# Altium schematic sheet MAIN.SchDoc(MAIN)
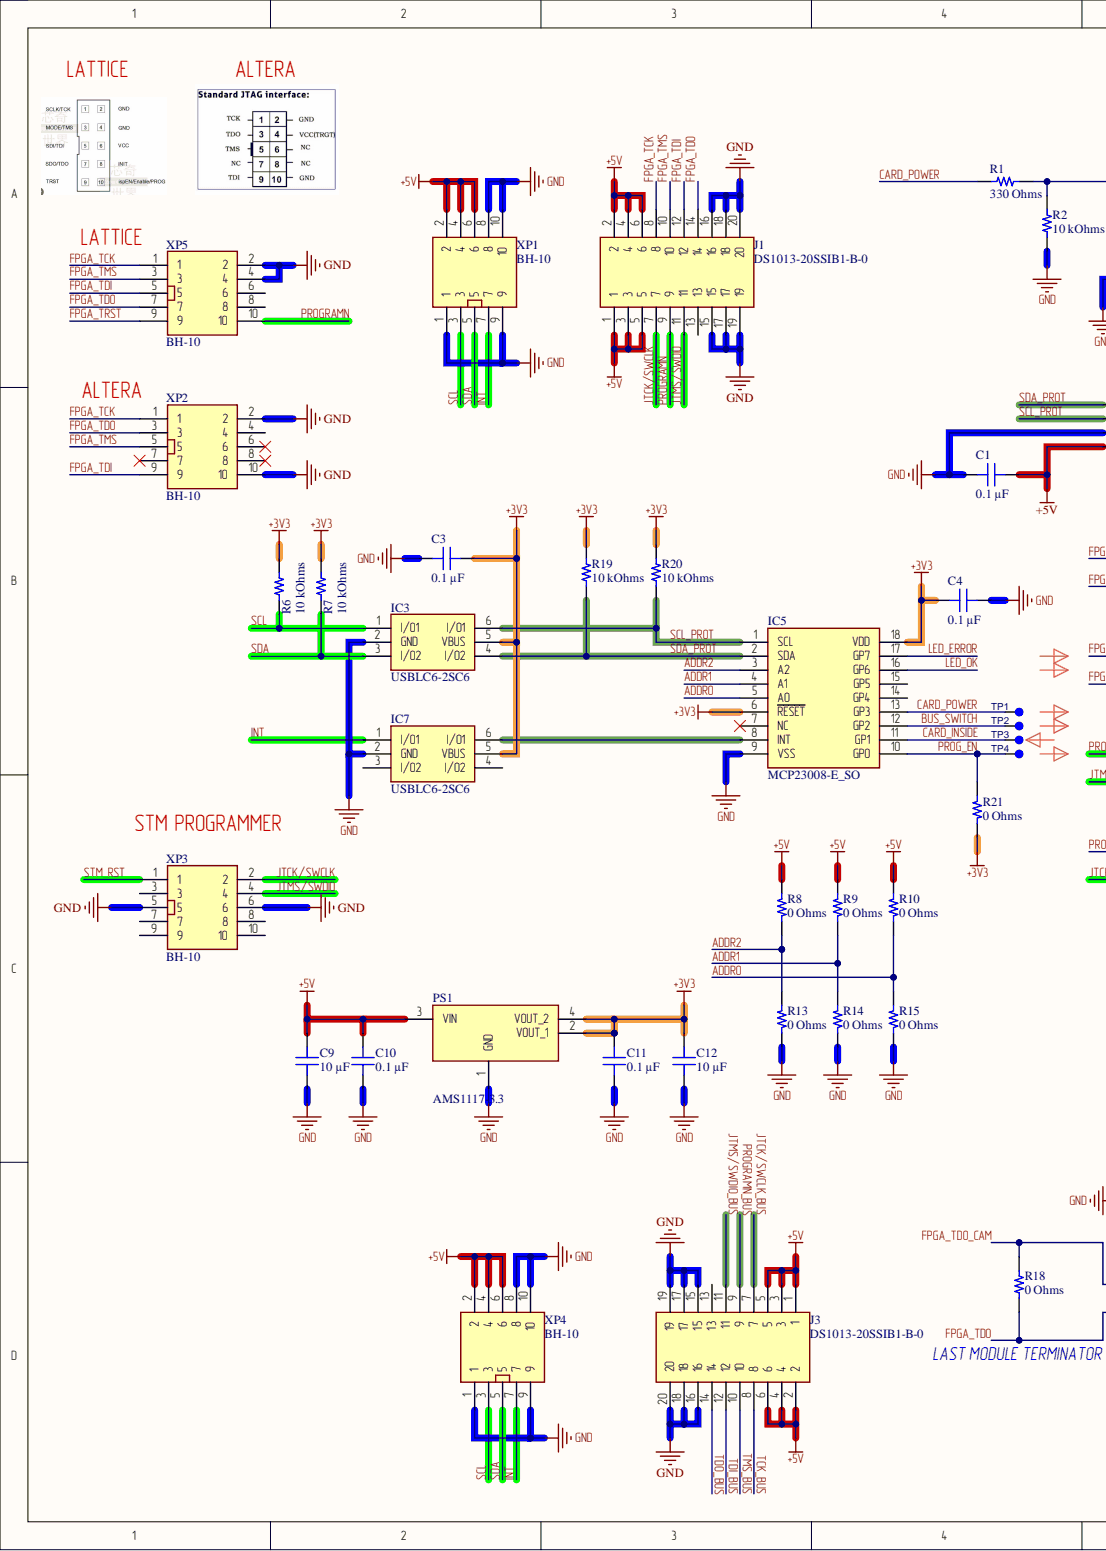
[diagram p0: MAIN.SchDoc, left]
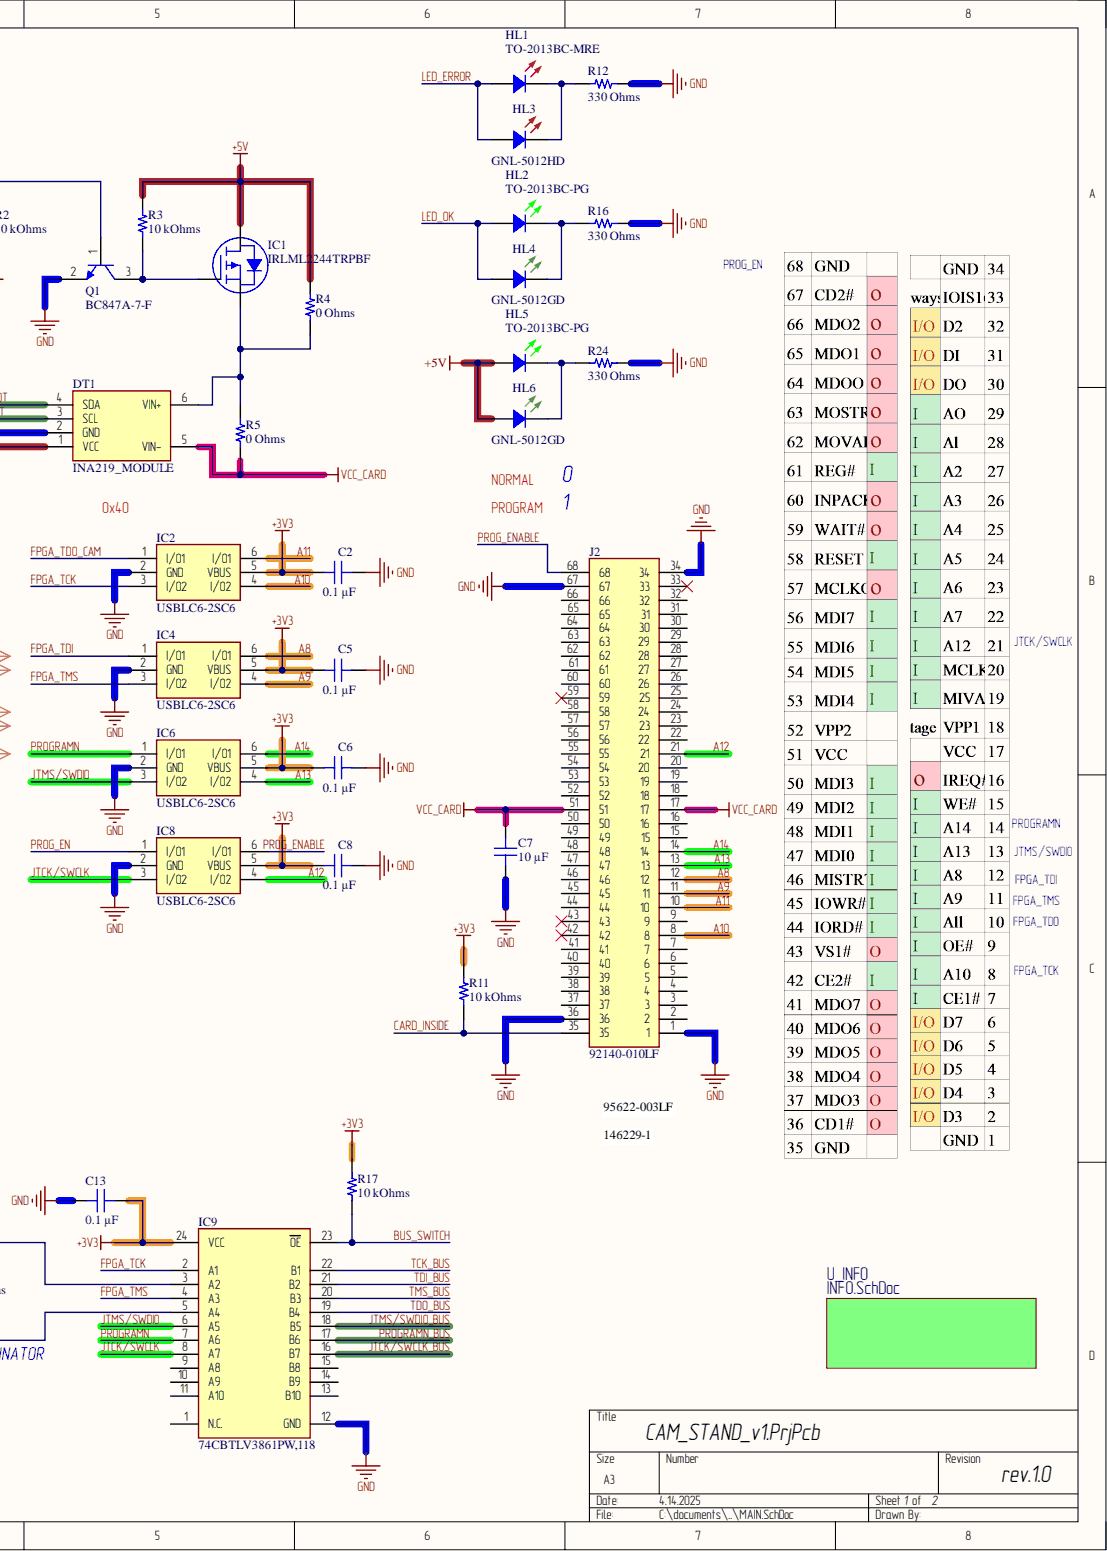
[diagram p1: MAIN.SchDoc, right]

## Parts
C1  0.1 µF  [CAP 0805_2012]
C2  0.1 µF  [CAP 0603_1608]
C3  0.1 µF  [CAP 0603_1608]
C4  0.1 µF  [CAP 0603_1608]
C5  0.1 µF  [CAP 0603_1608]
C6  0.1 µF  [CAP 0603_1608]
C7  10 µF  [CAP 0805_2012]
C8  0.1 µF  [CAP 0603_1608]
C9  10 µF  [CAP 0805_2012]
C10  0.1 µF  [CAP 0603_1608]
C11  0.1 µF  [CAP 0603_1608]
C12  10 µF  [CAP 0805_2012]
C13  0.1 µF  [CAP 0603_1608]
DT1  INA219_MODULE  [INA219_MODULE]
HL1  TO-2013BC-MRE  [LEDC2012X110N_RED]
HL2  TO-2013BC-PG  [LEDC2012X110N]
HL3  GNL-5012HD  [LED_5mm_red]
HL4  GNL-5012GD  [LED_5mm_green]
HL5  TO-2013BC-PG  [LEDC2012X110N]
HL6  GNL-5012GD  [LED_5mm_green]
IC1  IRLML2244TRPBF  [INFINEON SOT-23-3]
IC2  USBLC6-2SC6  [SOT95P280X145-6N]
IC3  USBLC6-2SC6  [SOT95P280X145-6N]
IC4  USBLC6-2SC6  [SOT95P280X145-6N]
IC5  MCP23008-E_SO  [SOIC127P1030X265-18N]
IC6  USBLC6-2SC6  [SOT95P280X145-6N]
IC7  USBLC6-2SC6  [SOT95P280X145-6N]
IC8  USBLC6-2SC6  [SOT95P280X145-6N]
IC9  74CBTLV3861PW,118  [SOP65P640X110-24N]
J1  DS1013-20SSIB1-B-0  [SHDR20W69P254X254_2X10_3300X910X955P]
J2  92140-010LF  [92140010LF]
J3  DS1013-20SSIB1-B-0  [SHDR20W69P254X254_2X10_3300X910X955P]
PS1  AMS1117-3.3  [SOT229P700X180-4N]
Q1  BC847A-7-F  [DIODES SOT-23-3]
R1  330 Ohms  [YAGEO RES 0603_1608]
R2  10 kOhms  [YAGEO RES 0603_1608]
R3  10 kOhms  [YAGEO RES 0603_1608]
R4  0 Ohms  [YAGEO RES 0805_2012]
R5  0 Ohms  [YAGEO RES 0805_2012]
R6  10 kOhms  [YAGEO RES 0603_1608]
R7  10 kOhms  [YAGEO RES 0603_1608]
R8  0 Ohms  [YAGEO RES 0805_2012]
R9  0 Ohms  [YAGEO RES 0805_2012]
R10  0 Ohms  [YAGEO RES 0805_2012]
R11  10 kOhms  [YAGEO RES 0603_1608]
R12  330 Ohms  [YAGEO RES 0603_1608]
R13  0 Ohms  [YAGEO RES 0805_2012]
R14  0 Ohms  [YAGEO RES 0805_2012]
R15  0 Ohms  [YAGEO RES 0805_2012]
R16  330 Ohms  [YAGEO RES 0603_1608]
R17  10 kOhms  [YAGEO RES 0603_1608]
R18  0 Ohms  [YAGEO RES 0603_1608]
R19  10 kOhms  [YAGEO RES 0603_1608]
R20  10 kOhms  [YAGEO RES 0603_1608]
R21  0 Ohms  [YAGEO RES 0805_2012]
R24  330 Ohms  [YAGEO RES 0603_1608]
TP1  PAD_TEST  [PAD_TEST]  (value not drawn)  (no BOM)
TP2  PAD_TEST  [PAD_TEST]  (value not drawn)  (no BOM)
TP3  PAD_TEST  [PAD_TEST]  (value not drawn)  (no BOM)
TP4  PAD_TEST  [PAD_TEST]  (value not drawn)  (no BOM)
XP1  BH-10  [BH-10]
XP2  BH-10  [BH-10]
XP3  BH-10  [BH-10]
XP4  BH-10  [BH-10]
XP5  BH-10  [BH-10]

## Nets
+3V3: C2.1 C3.2 C4.2 C5.1 C6.1 C8.1 C11.1 C12.1 C13.2 IC2.5(VBUS) IC3.5(VBUS) IC4.5(VBUS) IC5.6(RESET) IC5.18(VDD) IC6.5(VBUS) IC7.5(VBUS) IC8.5(VBUS) IC9.24(VCC) PS1.2(VOUT_1) PS1.4(VOUT_2) R6.2 R7.2 R11.2 R17.2 R19.2 R20.2 R21.2
+5V: C1.1 C9.1 C10.1 DT1.1(VCC) HL5.1 HL6.2 IC1.3 J1.1 J1.2 J1.3 J1.4 J1.5 J1.6 J3.1 J3.2 J3.3 J3.4 J3.5 J3.6 PS1.3(VIN) R3.2 R4.2 R8.1 R9.1 R10.1 XP1.2 XP1.4 XP1.6 XP4.2 XP4.4 XP4.6
GND: C1.2 C2.2 C3.1 C4.1 C5.2 C6.2 C7.2 C8.2 C9.2 C10.2 C11.2 C12.2 C13.1 DT1.2(GND) IC2.2(GND) IC3.2(GND) IC4.2(GND) IC5.9(VSS) IC6.2(GND) IC7.2(GND) IC8.2(GND) IC9.12(GND) J1.15 J1.16 J1.17 J1.18 J1.19 J1.20 J2.1 J2.34 J2.36 J2.67 J3.15 J3.16 J3.17 J3.18 J3.19 J3.20 PS1.1(GND) Q1.2 R2.1 R12.1 R13.2 R14.2 R15.2 R16.1 R24.1 XP1.1 XP1.8 XP1.9 XP1.10 XP2.2 XP2.10 XP3.5 XP3.6 XP4.1 XP4.8 XP4.9 XP4.10 XP5.2 XP5.4
VCC_CARD: C7.1 DT1.5(VIN-) J2.17 J2.51 R5.1
A8: IC4.6(I/O1) J2.12
A9: IC4.4(I/O2) J2.11
A10: IC2.4(I/O2) J2.8
A11: IC2.6(I/O1) J2.10
A12: IC8.4(I/O2) J2.21
A13: IC6.4(I/O2) J2.13
A14: IC6.6(I/O1) J2.14
ADDR0: IC5.5(A0) R10.2 R15.1
ADDR1: IC5.4(A1) R9.2 R14.1
ADDR2: IC5.3(A2) R8.2 R13.1
BUS_SWITCH: IC5.12(GP2) IC9.23(OE) R17.1 TP2.1
CARD_INSIDE: IC5.11(GP1) J2.35 R11.1 TP3.1
CARD_POWER: IC5.13(GP3) R1.2 TP1.1
FPGA_TCK: IC2.3(I/O2) IC9.2(A1) J1.8 XP2.1 XP5.1
FPGA_TDI: IC4.1(I/O1) J1.12 XP2.9 XP5.5
FPGA_TDO: IC9.5(A4) J1.14 R18.2 XP2.3 XP5.7
FPGA_TDO_CAM: IC2.1(I/O1) IC9.3(A2) R18.1
FPGA_TMS: IC4.3(I/O2) IC9.4(A3) J1.10 XP2.5 XP5.3
FPGA_TRST: XP5.9
INT: IC7.1(I/O1) XP1.7 XP4.7
JTCK/SWCLK: IC8.3(I/O2) IC9.8(A7) J1.7 XP3.2
JTCK/SWCLK_BUS: IC9.16(B7) J3.7
JTMS/SWDIO: IC6.3(I/O2) IC9.6(A5) J1.11 XP3.4
JTMS/SWDIO_BUS: IC9.18(B5) J3.11
LED_ERROR: HL1.1 HL3.2 IC5.17(GP7)
LED_OK: HL2.1 HL4.2 IC5.16(GP6)
PROG_EN: IC5.10(GP0) IC8.1(I/O1) R21.1 TP4.1
PROG_ENABLE: IC8.6(I/O1) J2.68
PROGRAMN: IC6.1(I/O1) IC9.7(A6) J1.9 XP5.10
PROGRAMN_BUS: IC9.17(B6) J3.9
SCL: IC3.1(I/O1) R6.1 XP1.3 XP4.3
SCL_PROT: DT1.3(SCL) IC3.6(I/O1) IC5.1(SCL) R20.1
SDA: IC3.3(I/O2) R7.1 XP1.5 XP4.5
SDA_PROT: DT1.4(SDA) IC3.4(I/O2) IC5.2(SDA) R19.1
STM_RST: XP3.1
TCK_BUS: IC9.22(B1) J3.8
TDI_BUS: IC9.21(B2) J3.12
TDO_BUS: IC9.19(B4) J3.14
TMS_BUS: IC9.20(B3) J3.10
NetDT1_6: DT1.6(VIN+) IC1.2 R4.1 R5.2
NetHL1_2: HL1.2 HL3.1 R12.2
NetHL2_2: HL2.2 HL4.1 R16.2
NetHL5_2: HL5.2 HL6.1 R24.2
NetIC1_1: IC1.1 Q1.3 R3.1
NetIC5_8: IC5.8(INT) IC7.6(I/O1)
NetQ1_1: Q1.1 R1.1 R2.2

Not connected: IC5 7(NC) 14(GP4) 15(GP5); IC7 3(I/O2) 4(I/O2); IC9 1(N.C.) 9(A8) 10(A9) 11(A10) 13(B10) 14(B9) 15(B8); J1 13; J2 2 3 4 5 6 7 9 15 16 18 19 20 22 23 24 25 26 27 28 29 30 31 32 33 37 38 39 40 41 42 43 44 45 46 47 48 49 50 52 53 54 55 56 57 58 59 60 61 62 63 64 65 66; J3 13; XP2 4 6 7 8; XP3 3 7 8 9 10; XP5 6 8

## Library data of the parts
C1 = CC0805KRX7R9BB104: CAP CER 0.1UF 50V X7R 0805; manufacturer: Yageo; Database Table Name: Capacitors - Ceramic - 0805; Tolerance: ±10%; Applications: General Purpose; Capacitance: 0.1 µF; Component Kind: Standard; componentlink1description: Datasheet; componentlink1url: https://www.yageo.com/upload/media/product/productsearch/datasheet/mlcc/UPY-GPHC_X7R_6.3V-to-50V_18.pdf; componentlink2description: DigiKey Link; componentlink2url: https://www.digikey.com/en/products/detail/yageo/CC0805KRX7R9BB104/302874; Device Package: 0805 (2012 Metric); Features: High Voltage; lastupdated: 09.02.2024 20:15:42; Library Name: Celestial Altium Library - altium_library.DbLib; Lifecycle Status: Active; Minimum Order: 1; Mounting Type: Surface Mount, MLCC; Operating Temperature: -55°C ~ 125°C; Package / Case: 0805 (2012 Metric); packaging: TapeAndReel; Part Number: CC0805KRX7R9BB104; Part Status: Active; partid: 12715; price: 0.01; series: CC; Size / Dimension: 0.079" L x 0.049" W (2.00mm x 1.25mm); Supplier 1: DigiKey; Supplier Part Number 1: 311-1140-1-ND; Temperature Coefficient: X7R; Thickness (Max): 0.033" (0.85mm); Value: 0.1 µF; Voltage - Rated: 50V
C2-C6 C8 C10 C11 C13 = CC0603KRX7R7BB104: CAP CER 0.1UF 16V X7R 0603; manufacturer: Yageo; Database Table Name: Capacitors - Ceramic - 0603; Tolerance: ±10%; Applications: General Purpose; Capacitance: 0.1 µF; Component Kind: Standard; componentlink1description: Datasheet; componentlink1url: https://www.yageo.com/upload/media/product/productsearch/datasheet/mlcc/UPY-GPHC_X7R_6.3V-to-50V_18.pdf; componentlink2description: DigiKey Link; componentlink2url: https://www.digikey.com/en/products/detail/yageo/CC0603KRX7R7BB104/302822; Device Package: 0603 (1608 Metric); lastupdated: 09.02.2024 20:10:09; Library Name: Celestial Altium Library - altium_library.DbLib; Lifecycle Status: Active; Minimum Order: 1; Mounting Type: Surface Mount, MLCC; Operating Temperature: -55°C ~ 125°C; Package / Case: 0603 (1608 Metric); packaging: TapeAndReel; Part Number: CC0603KRX7R7BB104; Part Status: Active; partid: 16746; price: 0.01; series: CC; Size / Dimension: 0.063" L x 0.031" W (1.60mm x 0.80mm); Supplier 1: DigiKey; Supplier Part Number 1: 311-1088-1-ND; Temperature Coefficient: X7R; Thickness (Max): 0.035" (0.90mm); Value: 0.1 µF; Voltage - Rated: 16V
C7 C9 C12 = CC0805KRX5R7BB106: CAP CER 10UF 16V X5R 0805; manufacturer: Yageo; Database Table Name: Capacitors - Ceramic - 0805; Tolerance: ±10%; Applications: General Purpose; Capacitance: 10 µF; Component Kind: Standard; componentlink1description: Datasheet; componentlink1url: https://www.yageo.com/upload/media/product/productsearch/datasheet/mlcc/UPY-GPHC_X5R_4V-to-50V_25.pdf; componentlink2description: DigiKey Link; componentlink2url: https://www.digikey.com/en/products/detail/yageo/CC0805KRX5R7BB106/7164386; Device Package: 0805 (2012 Metric); lastupdated: 09.02.2024 20:15:29; Library Name: Celestial Altium Library - altium_library.DbLib; Lifecycle Status: Active; Minimum Order: 1; Mounting Type: Surface Mount, MLCC; Operating Temperature: -55°C ~ 85°C; Package / Case: 0805 (2012 Metric); packaging: TapeAndReel; Part Number: CC0805KRX5R7BB106; Part Status: Active; partid: 16087; price: 0.09; series: CC; Size / Dimension: 0.079" L x 0.049" W (2.00mm x 1.25mm); Supplier 1: DigiKey; Supplier Part Number 1: 311-3502-1-ND; Temperature Coefficient: X5R; Thickness (Max): 0.057" (1.45mm); Value: 10 µF; Voltage - Rated: 16V
DT1 = INA219_MODULE: Current Sensor module, 3.2A, 26V; Manufacturer_Name: Vishay; Manufacturer_Part_Number: DF01SA-E3/45; Arrow Part Number: DF01SA-E3/45; Arrow Price/Stock: https://www.arrow.com/en/products/df01sa-e345/vishay?region=nac; Component Kind: Standard; Datasheet Link: https://www.arrow.com/en/products/df01sa-e345/vishay; Height: 3.63mm; Library Name: SamacSys.SchLib; Mouser Part Number: 625-DF01SA-E3; Mouser Price/Stock: https://www.mouser.co.uk/ProductDetail/Vishay-General-Semiconductor/DF01SA-E3-45?qs=lTgc38YOCCrpaTKQDA1AVA%3D%3D
HL1 = TO-2013BC-MRE: LED, 0805, Red; Manufacturer_Name: OASISTEK; Arrow Part Number: KP-2012ID; Arrow Price/Stock: https://www.arrow.com/en/products/kp-2012mgc/kingbright; Component Kind: Standard; Datasheet Link: https://static.chipdip.ru/lib/736/DOC002736052.pdf; Height: 1.1mm; Library Name: SamacSys.SchLib; Manufacturer_Part_Number: TO-2013BC-MRE; Mouser Part Number: N/A; Mouser Price/Stock: https://www.mouser.co.uk/ProductDetail/Kingbright/KP-2012MGC?qs=2JU0tDl2GZ0DzyNwePJZSw%3D%3D
HL2 HL5 = TO-2013BC-PG: LED, 0805, Green; Manufacturer_Name: OASISTEK; Arrow Part Number: KP-2012MGC; Arrow Price/Stock: https://www.arrow.com/en/products/kp-2012mgc/kingbright; Component Kind: Standard; Datasheet Link: https://static.chipdip.ru/lib/736/DOC002736056.pdf; Height: 1.1mm; Library Name: SamacSys.SchLib; Manufacturer_Part_Number: TO-2013BC-PG; Mouser Part Number: N/A; Mouser Price/Stock: https://www.mouser.co.uk/ProductDetail/Kingbright/KP-2012MGC?qs=2JU0tDl2GZ0DzyNwePJZSw%3D%3D
HL3 = GNL-5012HD: LED, 5mm, Red; Manufacturer_Name: G-NOR ELECTRONICS; Component Kind: Standard; Datasheet Link: https://static.chipdip.ru/lib/815/DOC059815856.pdf; Library Name: SamacSys.SchLib; Manufacturer_Part_Number: GNL-5012HD
HL4 HL6 = GNL-5012GD: LED, 5mm, Green; Manufacturer_Name: G-NOR ELECTRONICS; Component Kind: Standard; Datasheet Link: https://static.chipdip.ru/lib/796/DOC059796154.pdf; Library Name: SamacSys.SchLib; Manufacturer_Part_Number: GNL-5012GD
IC1 = IRLML2244TRPBF: MOSFET P-CH 20V 4.3A SOT23; Manufacturer: Infineon Technologies; Current - Continuous Drain (Id) @ 25°C: 4.3A (Ta); Database Table Name: MOSFET - Single - P-Ch; Drive Voltage (Max Rds On,  Min Rds On): 2.5V, 4.5V; FET Type: P-Channel; Gate Charge (Qg) (Max) @ Vgs: 6.9 nC @ 4.5 V; Input Capacitance (Ciss) (Max) @ Vds: 570 pF @ 16 V; Power Dissipation (Max): 1.3W (Ta); Rds On (Max) @ Id, Vgs: 54mOhm @ 4.3A, 4.5V; Vgs (Max): ±12V; Vgs(th) (Max) @ Id: 1.1V @ 10µA; Component Kind: Standard; ComponentLink1Description: Datasheet; ComponentLink1URL: https://www.infineon.com/dgdl/irlml2244pbf.pdf?fileId=5546d462533600a401535664bfff25f0; ComponentLink2Description: DigiKey Link; ComponentLink2URL: https://www.digikey.com/en/products/detail/infineon-technologies/IRLML2244TRPBF/2639564; Drain to Source Voltage (Vdss): 20 V; LastUpdated: 03.06.2024 20:15:24; Library Name: Celestial Altium Library - altium_library.DbLib; Lifecycle Status: Active; Minimum Order: 1; Mounting Type: Surface Mount; Operating Temperature: -55°C ~ 150°C (TJ); Package / Case: TO-236-3, SC-59, SOT-23-3; Packaging: TapeAndReel; Part Number: IRLML2244TRPBF; Part Status: Active; Price: 0.08; Series: HEXFET®; Supplier 1: DigiKey; Supplier Device Package: Micro3™/SOT-23; Supplier Part Number 1: IRLML2244TRPBFCT-ND; Technology: MOSFET (Metal Oxide)
IC2-IC4 IC6-IC8 = USBLC6-2SC6: Integrated Circuit; Manufacturer_Name: STMicroelectronics; Arrow Part Number: USBLC6-2SC6; Arrow Price/Stock: https://www.arrow.com/en/products/usblc6-2sc6/stmicroelectronics?region=nac; Component Kind: Standard; Datasheet Link: http://www.st.com/st-web-ui/static/active/en/resource/technical/document/datasheet/CD00050750.pdf; Height: 1.45mm; Library Name: SamacSys.SchLib; Manufacturer_Part_Number: USBLC6-2SC6; Mouser Part Number: 511-USBLC6-2SC6; Mouser Price/Stock: https://www.mouser.co.uk/ProductDetail/STMicroelectronics/USBLC6-2SC6?qs=po45yt2pPpu%2FhNIlwQdTlg%3D%3D
IC5 = MCP23008-E_SO: Integrated Circuit; Manufacturer_Name: Microchip; Manufacturer_Part_Number: MCP23008-E/SO; Arrow Part Number: MCP23008-E/SO; Arrow Price/Stock: https://www.arrow.com/en/products/mcp23008-eso/microchip-technology?region=nac; Component Kind: Standard; Datasheet Link: https://datasheet.datasheetarchive.com/originals/distributors/SFDatasheet-4/sf-00090055.pdf; Height: 2.65mm; Library Name: SamacSys.SchLib; Mouser Part Number: 579-MCP23008-E/SO; Mouser Price/Stock: https://www.mouser.co.uk/ProductDetail/Microchip-Technology/MCP23008-E-SO?qs=Sez7gRs8XSWum74ZwQXTLw%3D%3D
IC9 = 74CBTLV3861PW,118: Integrated Circuit; Manufacturer_Name: Nexperia; Component Kind: Standard; Datasheet Link: https://assets.nexperia.com/documents/data-sheet/74CBTLV3861.pdf; Height: 1.1mm; Library Name: SamacSys.SchLib; Manufacturer_Part_Number: 74CBTLV3861PW,118
J1 J3 = DS1013-20SSIB1-B-0: Connector; Manufacturer_Name: Connfly; Component Kind: Standard; Datasheet Link: https://www.tme.eu/Document/e9117e1c80532ff2c20cc520b4694ed9/DS1013.pdf; Height: 9.55mm; Library Name: SamacSys.SchLib; Manufacturer_Part_Number: DS1013-20SSIB1-B-0
J2 = 92140-010LF: Connector; Manufacturer_Name: Amphenol Communications Solutions; Arrow Part Number: 92140-010LF; Arrow Price/Stock: https://www.arrow.com/en/products/92140-010lf/amphenol-fci; Component Kind: Standard; Datasheet Link: https://cdn.amphenol-cs.com/media/wysiwyg/files/documentation/datasheet/inputoutput/io_pcmcia_shortbody.pdf; Height: 5.8mm; Manufacturer_Part_Number: 92140-010LF; Mouser Part Number: 649-92140-010LF; Mouser Price/Stock: https://www.mouser.co.uk/ProductDetail/Amphenol-FCI/92140-010LF?qs=S7cSk136QBGr8%252BBNYNafTg%3D%3D
PS1 = AMS1117-3.3: LDO regulator; Manufacturer_Name: Advanced Monolithic Systems; Component Kind: Standard; Datasheet Link: http://www.advanced-monolithic.com/pdf/ds1117.pdf; Height: 1.8mm; Library Name: SamacSys.SchLib; Manufacturer_Part_Number: AMS1117-3.3
Q1 = BC847A-7-F: TRANS NPN 45V 0.1A SOT23-3; Manufacturer: Diodes Incorporated; Current - Collector (Ic) (Max): 100 mA; Current - Collector Cutoff (Max): 15nA; Database Table Name: Transistors - Bipolar BJT - Single; DC Current Gain (hFE) (Min) @ Ic, Vce: 110 @ 2mA, 5V; Frequency - Transition: 300MHz; Power - Max: 300 mW; Vce Saturation (Max) @ Ib, Ic: 600mV @ 5mA, 100mA; Component Kind: Standard; ComponentLink1Description: Datasheet; ComponentLink1URL: https://www.diodes.com/assets/Datasheets/ds11108.pdf; ComponentLink2Description: DigiKey Link; ComponentLink2URL: https://www.digikey.com/en/products/detail/diodes-incorporated/BC847A-7-F/1934448; LastUpdated: 03.06.2024 20:24:11; Library Name: Celestial Altium Library - altium_library.DbLib; Lifecycle Status: Active; Minimum Order: 1; Mounting Type: Surface Mount; Operating Temperature: -65°C ~ 150°C (TJ); Package / Case: TO-236-3, SC-59, SOT-23-3; Packaging: TapeAndReel; Part Number: BC847A-7-F; Part Status: Active; PartId: 122; Price: 0.02; Series: -; Supplier 1: DigiKey; Supplier Device Package: SOT-23-3; Supplier Part Number 1: BC847A-7FDICT-ND; Transistor Type: NPN; Voltage - Collector Emitter Breakdown (Max): 45 V
R1 R12 R16 R24 = RC0603FR-07330RL: RES 330 OHM 1% 1/10W 0603; Manufacturer: Yageo; Database Table Name: Resistors - Surface Mount - 0603; Power (Watts): 0.1W, 1/10W; Temperature Coefficient: ±100ppm/°C; Component Kind: Standard; ComponentLink1Description: Datasheet; ComponentLink1URL: https://www.yageo.com/upload/media/product/productsearch/datasheet/rchip/PYu-RC_Group_51_RoHS_L_10.pdf; ComponentLink2Description: DigiKey Link; ComponentLink2URL: https://www.digikey.com/en/products/detail/yageo/RC0603FR-07330RL/727162; Composition: Thick Film; Device Package: 0603 (1608 Metric); Features: Moisture Resistant; Height - Seated (Max): 0.022" (0.55mm); LastUpdated: 25.07.2024 9:19:17; Library Name: Celestial Altium Library - altium_library.DbLib; Lifecycle Status: Active; Minimum Order: 1; Number of Terminations: 2; Operating Temperature: -55°C ~ 155°C; Package / Case: 0603 (1608 Metric); Packaging: CutTape; Part Number: RC0603FR-07330RL; Part Status: Active; PartId: 23956; Price: 0.01; Resistance: 330 Ohms; Series: RC_L; Size / Dimension: 0.063" L x 0.031" W (1.60mm x 0.80mm); Supplier 1: DigiKey; Supplier Device Package: 0603; Supplier Part Number 1: 311-330HRCT-ND; Tolerance: ±1%; Value: 330 Ohms
R2 R3 R6 R7 R11 R17 R19 R20 = RC0603FR-0710KL: RES 10K OHM 1% 1/10W 0603; Manufacturer: Yageo; Database Table Name: Resistors - Surface Mount - 0603; Power (Watts): 0.1W, 1/10W; Temperature Coefficient: ±100ppm/°C; Component Kind: Standard; ComponentLink1Description: Datasheet; ComponentLink1URL: https://www.yageo.com/upload/media/product/productsearch/datasheet/rchip/PYu-RC_Group_51_RoHS_L_10.pdf; ComponentLink2Description: DigiKey Link; ComponentLink2URL: https://www.digikey.com/en/products/detail/yageo/RC0603FR-0710KL/726880; Composition: Thick Film; Device Package: 0603 (1608 Metric); Features: Moisture Resistant; Height - Seated (Max): 0.022" (0.55mm); LastUpdated: 25.07.2024 9:19:19; Library Name: Celestial Altium Library - altium_library.DbLib; Lifecycle Status: Active; Minimum Order: 1; Number of Terminations: 2; Operating Temperature: -55°C ~ 155°C; Package / Case: 0603 (1608 Metric); Packaging: CutTape; Part Number: RC0603FR-0710KL; Part Status: Active; PartId: 22599; Price: 0.01; Resistance: 10 kOhms; Series: RC_L; Size / Dimension: 0.063" L x 0.031" W (1.60mm x 0.80mm); Supplier 1: DigiKey; Supplier Device Package: 0603; Supplier Part Number 1: 311-10.0KHRCT-ND; Tolerance: ±1%; Value: 10 kOhms
R4 R5 R8-R10 R13-R15 R21 = RC0805FR-070RL: RES 0 OHM JUMPER 1/8W 0805; Manufacturer: Yageo; Database Table Name: Resistors - Surface Mount - 0805; Power (Watts): 0.125W, 1/8W; Component Kind: Standard; ComponentLink1Description: Datasheet; ComponentLink1URL: https://www.yageo.com/upload/media/product/productsearch/datasheet/rchip/PYu-RC_Group_51_RoHS_L_10.pdf; ComponentLink2Description: DigiKey Link; ComponentLink2URL: https://www.digikey.com/en/products/detail/yageo/RC0805FR-070RL/2827620; Composition: Thick Film; Device Package: 0805 (2012 Metric); Features: Moisture Resistant; Height - Seated (Max): 0.024" (0.60mm); LastUpdated: 25.07.2024 9:20:16; Library Name: Celestial Altium Library - altium_library.DbLib; Lifecycle Status: Active; Minimum Order: 1; Number of Terminations: 2; Operating Temperature: -55°C ~ 155°C; Package / Case: 0805 (2012 Metric); Packaging: CutTape; Part Number: RC0805FR-070RL; Part Status: Active; PartId: 47635; Price: 0.01; Resistance: 0 Ohms; Series: RC_L; Size / Dimension: 0.079" L x 0.049" W (2.00mm x 1.25mm); Supplier 1: DigiKey; Supplier Device Package: 0805; Supplier Part Number 1: 311-0.0CRCT-ND; Tolerance: Jumper; Value: 0 Ohms
R18 = RC0603FR-070RL: RES 0 OHM JUMPER 1/10W 0603; Manufacturer: Yageo; Database Table Name: Resistors - Surface Mount - 0603; Power (Watts): 0.1W, 1/10W; Component Kind: Standard; ComponentLink1Description: Datasheet; ComponentLink1URL: https://www.yageo.com/upload/media/product/productsearch/datasheet/rchip/PYu-RC_Group_51_RoHS_L_10.pdf; ComponentLink2Description: DigiKey Link; ComponentLink2URL: https://www.digikey.com/en/products/detail/yageo/RC0603FR-070RL/1304008; Composition: Thick Film; Device Package: 0603 (1608 Metric); Features: Moisture Resistant; Height - Seated (Max): 0.022" (0.55mm); LastUpdated: 25.07.2024 9:19:19; Library Name: Celestial Altium Library - altium_library.DbLib; Lifecycle Status: Active; Minimum Order: 1; Number of Terminations: 2; Operating Temperature: -55°C ~ 155°C; Package / Case: 0603 (1608 Metric); Packaging: CutTape; Part Number: RC0603FR-070RL; Part Status: Active; PartId: 22614; Price: 0.01; Resistance: 0 Ohms; Series: RC_L; Size / Dimension: 0.063" L x 0.031" W (1.60mm x 0.80mm); Supplier 1: DigiKey; Supplier Device Package: 0603; Supplier Part Number 1: 311-0.0HRCT-ND; Tolerance: Jumper; Value: 0 Ohms
TP1-TP4 = PAD_TEST: Test Point; Manufacturer: {Manufacturer}; CatalogueCode: {CatalogueCode}; PowerVoltage: {PowerVoltage}; Reseller1: {Reseller1}; Reseller2: {Reseller2}; Reseller3: {Reseller3}; Tempcoeff: {Tempcoeff}; Tolerance: {Tolerance}; Value: .NetName; Case: {Case}; Library Name: SamacSys.SchLib
XP1-XP5 = BH-10_1: Connector; Manufacturer_Name: Connfly; Manufacturer_Part_Number: DS1013-10S; Manufacturer_Part_Number_1: IDC-10MS; Component Kind: Standard; Datasheet Link: https://static.chipdip.ru/lib/463/DOC002463525.pdf; Library Name: SamacSys.SchLib; System: Connector
